# Altium schematic sheet Sheet1.SchDoc
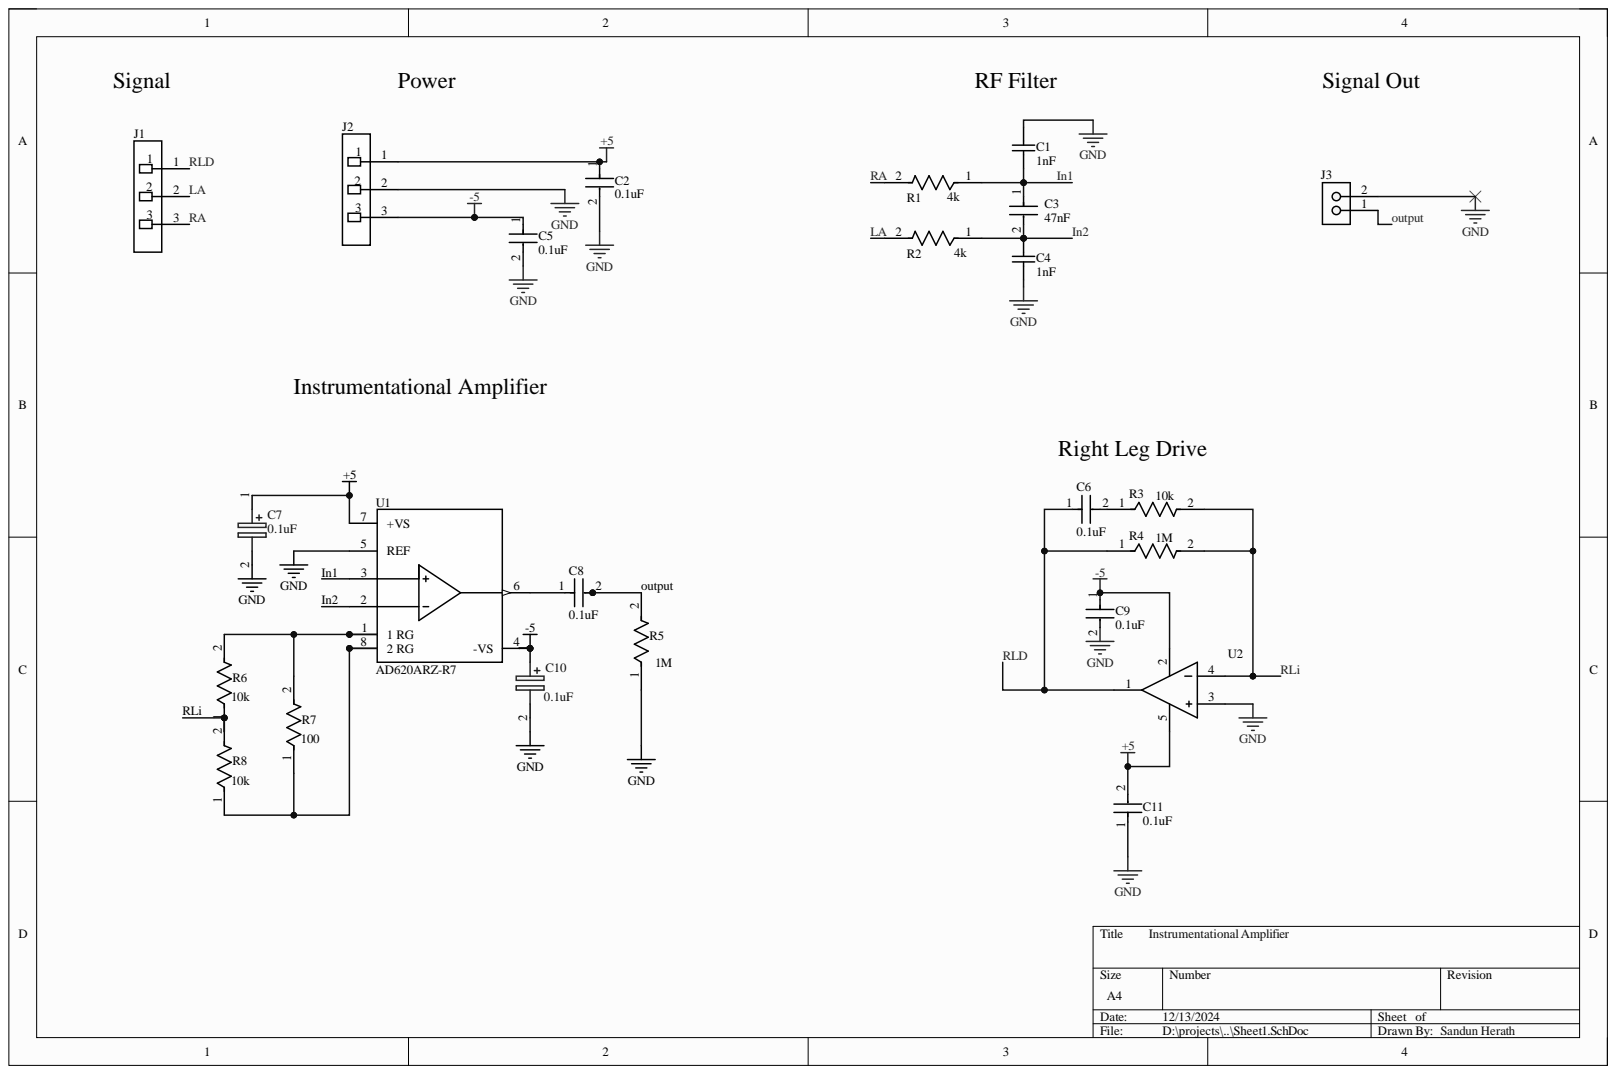
[diagram p0: Sheet1.SchDoc]

## Parts
C1  1nF  [CAPC0805(2012)145_L]
C2  0.1uF  [FP-CL21-IPC_A]
C3  47nF  [FP-GRM21B-0_1-IPC_C]
C4  1nF  [CAPC0805(2012)145_L]
C5  0.1uF  [FP-CL21-IPC_A]
C6  0.1uF  [FP-CL21-IPC_A]
C7  0.1uF  [CAPPM3216X180N]
C8  0.1uF  [FP-CL21-IPC_A]
C9  0.1uF  [FP-CL21-IPC_A]
C10  0.1uF  [CAPPM3216X180N]
C11  0.1uF  [FP-CL21-IPC_A]
J1  B3B-XH-A(LF)(SN)  [FP-B3B-XH-A_LF_SN-MFG]  (value not drawn)
J2  B3B-XH-A(LF)(SN)  [FP-B3B-XH-A_LF_SN-MFG]  (value not drawn)
J3  61300211121  [61300211121]  (value not drawn)
R1  4k  [FP-ERA6A-IPC_C]
R2  4k  [FP-ERA6A-IPC_C]
R3  10k  [FP-ERA6A-IPC_C]
R4  1M  [FP-ERA6A-IPC_C]
R5  1M  [FP-ERA6A-IPC_C]
R6  10k  [FP-ERA6A-IPC_C]
R7  100  [FP-ERA6A-IPC_C]
R8  10k  [FP-ERA6A-IPC_C]
U1  AD620ARZ-R7  [FP-R-8-IPC_C]
U2  TLV9151IDBVR  [FP-DBV0005A-IPC_A]  (value not drawn)

## Nets
+5: C2.1 C7.1 C11.2 J2.1 U1.7(+VS) U2.5
-5: C5.1 C9.1 C10.1 J2.3 U1.4(-VS) U2.2
GND: C1.2 C2.2 C4.1 C5.2 C7.2 C9.2 C10.2 C11.1 J2.2 J3.2 R5.1 U1.5(REF) U2.3
In1: C1.1 C3.1 R1.1 U1.3
In2: C3.2 C4.2 R2.1 U1.2
LA: J1.2 R2.2
output: C8.2 J3.1 R5.2
RA: J1.3 R1.2
RLD: C6.1 J1.1 R4.1 U2.1
RLi: R3.2 R4.2 R6.1 R8.2 U2.4
NetC6_2: C6.2 R3.1
NetC8_1: C8.1 U1.6
NetR6_2: R6.2 R7.2 U1.1(1_RG)
NetR7_1: R7.1 R8.1 U1.8(2_RG)

## Library data of the parts
C1 C4 = CMP-1036-02976-1: CAP 1nF 50V ±5% 0805 (2012 Metric) Thickness 1.45mm SMD; Case-EIA: 0805; Case-Metric: 2012; Tolerance: Â±5%; Component Kind: Standard; Library Name: Altium Content Vault; Max Thickness: 1.45 mm; Rated Voltage: 50 V; Technology: SMT; Value: 1nF
C2 C5 C6 C8 C9 C11 = CMP-13271-004391-1: CL21 Series 0805 100nF 25V ±20% Tolerance X7R Multilayer Ceramic Chip Capacitor, CL21B104MACNNNC; Capacitance: 100nF; Case Code (Imperial): 0805; Case Code (Metric): 2012; Case/Package: 0805; Component Kind: Standard; Construction: Flat; Depth: 1.25mm; Dielectric: X7R; Height: 0.85mm; Lead Free: Lead Free; Length: 2mm; Library Name: Altium Content Vault; Max Operating Temperature: 125°C; Min Operating Temperature: -55°C; Mount: Surface Mount; Package Quantity: 1; Packaging: Tape and Reel; Radiation Hardening: No; RoHS Compliant: Yes; Series: CL; Thickness: 0.037inch; Tolerance: 20%; Voltage Rating: 25V; Voltage Rating (DC): 25V; Width: 0.049inch
C3 = CMP-06035-029151-1: Chip Multilayer Ceramic Capacitors for General Purpose, 0805, 47000pF, SL, +350/-1000ppm/°C, 5%, 50V,   GRM21B1X1H473JA01L; Capacitance: 47nF; Case Code (Imperial): 0805; Case Code (Metric): 2012; Case/Package: 0805; Component Kind: Standard; Lead Free: Lead Free; Length: 0.079inch; Library Name: Altium Content Vault; Max Operating Temperature: 125°C; Min Operating Temperature: -55°C; Mount: Surface Mount; Packaging: Tape and Reel; Termination: SMD/SMT; Thickness: 0.053inch; Tolerance: 5%; Voltage Rating: 50V; Voltage Rating (DC): 50V; Width: 0.049inch
C7 C10 = 593D106X96R3A2TE3: Capacitor Polarised,  593D106X96R3A2TE3; Manufacturer_Name: Vishay; Component Kind: Standard; Datasheet Link: https://www.vishay.com/docs/40005/593d.pdf; Height: 1.8mm; Manufacturer_Part_Number: 593D106X96R3A2TE3; Mouser Part Number: N/A; Mouser Price/Stock: https://www.mouser.com/Search/Refine.aspx?Keyword=N%2FA
J1 J2 = CMP-17439-000014-3: CONN HEADER VERT 3POS 2.5MM; Contact Resistance: 20mR; Current Rating: 3A; Gender: Male; Insulation Resistance: 1GR; Max Voltage Rating (AC): 250V; Number of Contacts: 3; Wire/Cable Gauge: 22AWG; Color: Natural; Component Kind: Standard; Connector Type: Header; Contact Finish - Mating: TIN; Contact Material: Brass; Contact Plating: Tin; Contact Shape: Square; Contact Type: Male Pin; Depth: 5.75mm; Fastening Type: Detent; Flammability Rating: UL94 V-0; Housing Color: White; Housing Material: Polyamide; Insulation Color: Natural; Insulation Material: Nylon; Lead Free: Lead Free; Lead Pitch: 2.5mm; Length: 9.9mm; Library Name: Altium Content Vault; Max Current Rating: 3A; Max Operating Temperature: 85°C; Max Voltage Rating (DC): 250V; Min Operating Temperature: -25°C; Mount: Through Hole; Number of Positions: 3; Number of Rows: 1; Orientation: Straight; Packaging: Bulk; Pitch: 2.5mm; REACH SVHC: No SVHC; Series: XH; Termination: Solder; Voltage Rating: 250V; Voltage Rating (AC): 250V
J3 = CMP-1712-00002-6: NexarPartId: 20081794; Connector Type: Male Pin; Contact Resistance: 20mΩ; Current Rating: 3A; Gender: Male; Number of Contacts: 2; Operating Supply Voltage: 250V; Pitch: 2.54mm; Color: Black; Component Kind: Standard; Contact Gender: Male; Contact Material: Copper; Contact Mating Length: 5.9944mm; Contact Plating: Gold; Height: 8.94mm; Housing Material: PBT; Lead Free: Lead Free; Lead Pitch: 2.54mm; Library Name: Altium Content Vault; Max Operating Temperature: 125°C; Min Operating Temperature: -40°C; Mount: Through Hole; Number of Pins: 2; Number of Positions: 2; Number of Rows: 1; Orientation: Vertical; Packaging: Bulk; REACH SVHC: No SVHC; RoHS: Compliant; Termination: Through Hole; Voltage Rating: 250V
R1 R2 R4 R5 R7 R8 = CMP-2001-00581-2: RES 1K OHM 0.1% 1/8W 0805,  ERA6AEB102V; Max Power Dissipation: 125mW; Resistance: 1kR; Temperature Coefficient: 25ppm/°C; Voltage Rating: 100V; Case Code (Imperial): 0805; Case Code (Metric): 2012; Case/Package: 0805; Component Kind: Standard; Construction: Rectangular; Contact Plating: Tin; Depth: 1.25mm; Height: 0.5mm; Length: 2mm; Library Name: Altium Content Vault; Max Operating Temperature: 155°C; Military Standard: Not; Min Operating Temperature: -55°C; Mount: Surface Mount; Number of Pins: 2; Number of Terminations: 2; Package Quantity: 5000; Packaging: Tape and Reel; Power Rating: 125mW; Radiation Hardening: No; REACH SVHC: No SVHC; Resistance Tolerance: 0.1%; Resistor Type: Thin Film; RoHS Compliant: Yes; Series: ERA-6A; Technology: THIN FILM; Termination: SMD/SMT; Tolerance: 0.1%; Width: 1.25mm; Working Voltage: 100V
R3 = CMP-2001-00581-2: RES 1K OHM 0.1% 1/8W 0805,   ERA6AEB102V; Max Power Dissipation: 125mW; Resistance: 1kR; Temperature Coefficient: 25ppm/°C; Voltage Rating: 100V; Case Code (Imperial): 0805; Case Code (Metric): 2012; Case/Package: 0805; Component Kind: Standard; Construction: Rectangular; Contact Plating: Tin; Depth: 1.25mm; Height: 0.5mm; Length: 2mm; Library Name: Altium Content Vault; Max Operating Temperature: 155°C; Military Standard: Not; Min Operating Temperature: -55°C; Mount: Surface Mount; Number of Pins: 2; Number of Terminations: 2; Package Quantity: 5000; Packaging: Tape and Reel; Power Rating: 125mW; Radiation Hardening: No; REACH SVHC: No SVHC; Resistance Tolerance: 0.1%; Resistor Type: Thin Film; RoHS Compliant: Yes; Series: ERA-6A; Technology: THIN FILM; Termination: SMD/SMT; Tolerance: 0.1%; Width: 1.25mm; Working Voltage: 100V
R6 = CMP-2001-00581-2: RES 1K OHM 0.1% 1/8W 0805,    ERA6AEB102V; Max Power Dissipation: 125mW; Resistance: 1kR; Temperature Coefficient: 25ppm/°C; Voltage Rating: 100V; Case Code (Imperial): 0805; Case Code (Metric): 2012; Case/Package: 0805; Component Kind: Standard; Construction: Rectangular; Contact Plating: Tin; Depth: 1.25mm; Height: 0.5mm; Length: 2mm; Library Name: Altium Content Vault; Max Operating Temperature: 155°C; Military Standard: Not; Min Operating Temperature: -55°C; Mount: Surface Mount; Number of Pins: 2; Number of Terminations: 2; Package Quantity: 5000; Packaging: Tape and Reel; Power Rating: 125mW; Radiation Hardening: No; REACH SVHC: No SVHC; Resistance Tolerance: 0.1%; Resistor Type: Thin Film; RoHS Compliant: Yes; Series: ERA-6A; Technology: THIN FILM; Termination: SMD/SMT; Tolerance: 0.1%; Width: 1.25mm; Working Voltage: 100V
U1 = CMP-09116-000394-1: IC INST AMP 1 CIRCUIT 8SOIC; -3db Bandwidth: 1MHz; Amplifier Type: INSTRUMENTATION AMPLIFIER; Common Mode Rejection Ratio: 93dB; Input Bias Current: 1nA; Input Offset Voltage (Vos): 30mV; Input Resistance: 10GR; Max Dual Supply Voltage: 18V; Max Power Dissipation: 650mW; Min Dual Supply Voltage: 2.3V; Min Supply Voltage: 4.6V; Nominal Supply Current: 1.3mA; Number of Channels: 1; Output Current per Channel: 18mA; Slew Rate: 1.2V/µs; Case/Package: SOIC; Component Kind: Standard; Contact Plating: Tin; Lead Free: Contains Lead; Library Name: Altium Content Vault; Max Operating Temperature: 125°C; Min Operating Temperature: -55°C; Mount: Surface Mount; Number of Circuits: 1; Number of Elements: 1; Number of Pins: 8; Operating Supply Current: 1.3mA; Operating Supply Voltage: 18V; Package Quantity: 1; Packaging: Tape and Reel; Power Dissipation: 650mW; Radiation Hardening: No; RoHS Compliant: Yes; Series: -
U2 = CMP-04895-000336-1: OPAMP; Component Kind: Standard; Library Name: Altium Content Vault
